# Altium schematic sheet Misc.SchDoc(Misc)
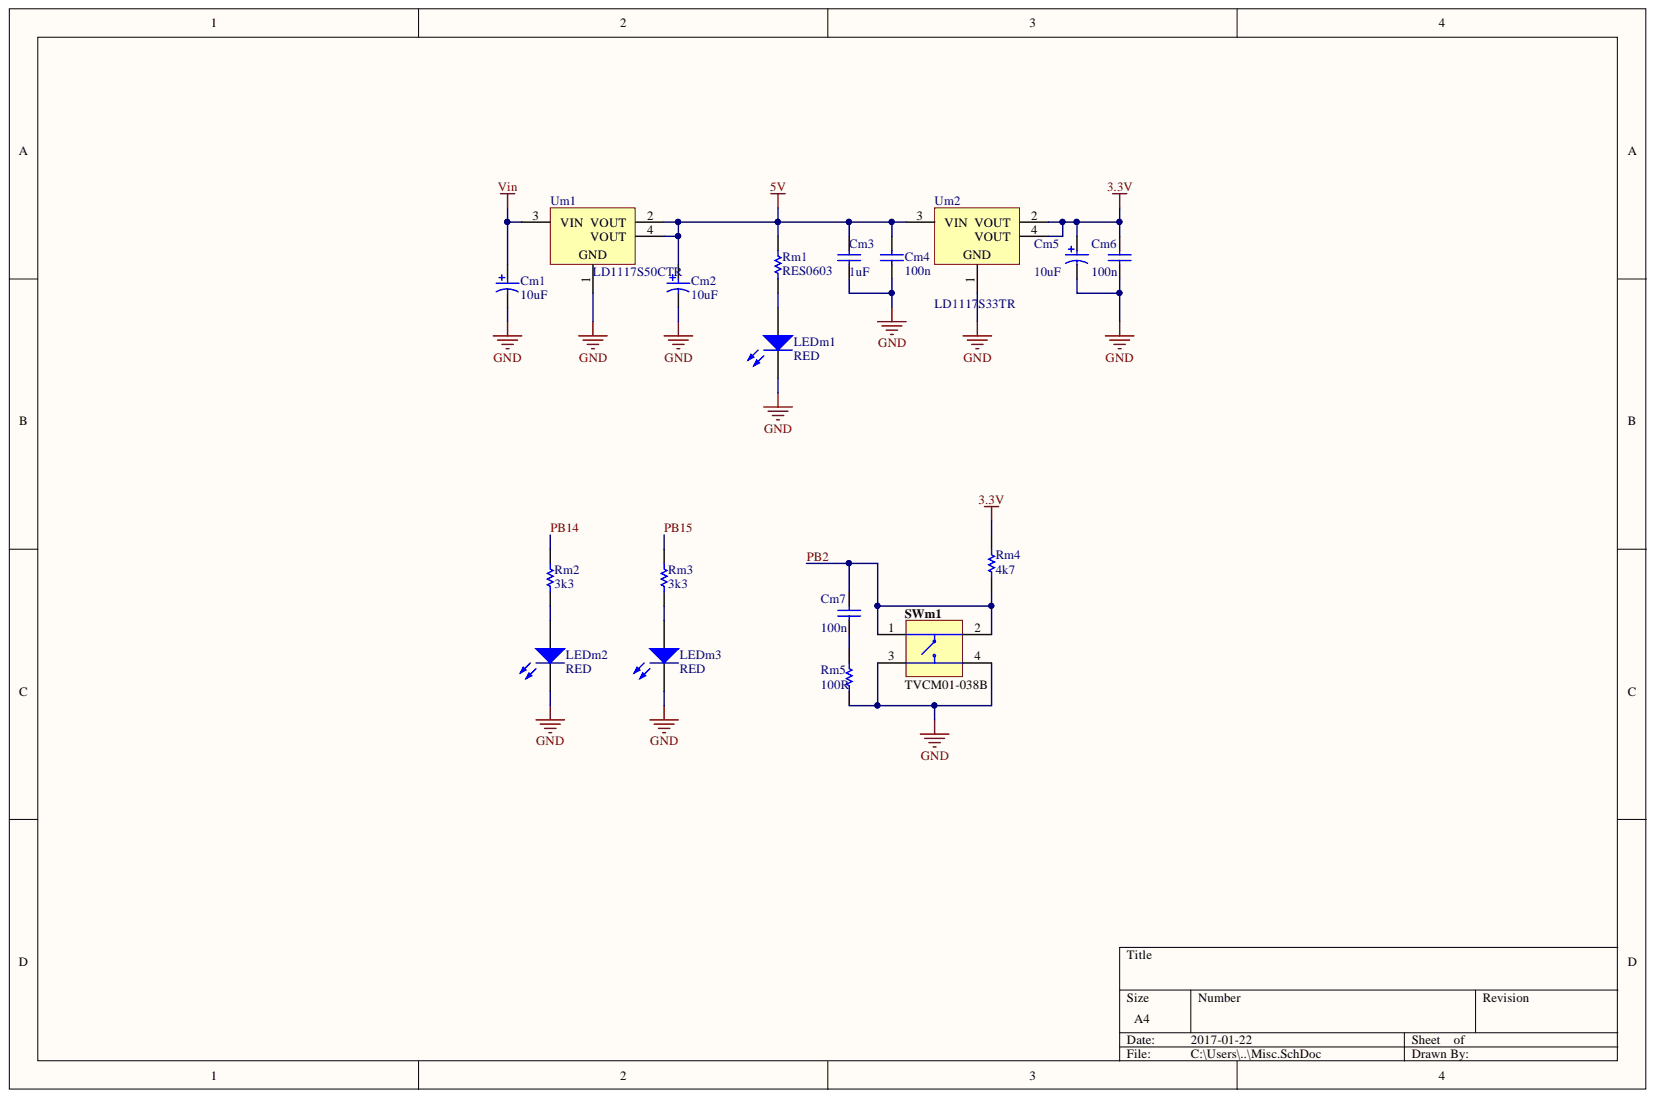
[diagram p0: Misc.SchDoc]

## Parts
Cm1  10uF  [CAPMP3217X18-T_V]
Cm2  10uF  [CAPMP3217X18-T_V]
Cm3  1uF  [CAPC0603(1608)100_L]
Cm4  100n  [CAPC0603(1608)100_L]
Cm5  10uF  [CAPMP3217X18-T_V]
Cm6  100n  [CAPC0603(1608)100_L]
Cm7  100n  [CAPC0603(1608)100_L]
LEDm1  RED  [0805_DIODE]
LEDm2  RED  [0805_DIODE]
LEDm3  RED  [0805_DIODE]
Rm1  RES0603  [RESC0603(1608)_L]
Rm2  3k3  [RESC0603(1608)_L]
Rm3  3k3  [RESC0603(1608)_L]
Rm4  4k7  [RESC0603(1608)_L]
Rm5  100R  [RESC0603(1608)_L]
SWm1  TVCM01-038B  [JNFU-TVCM01-038B_V]
Um1  LD1117S50CTR  [SOT-223_N]
Um2  LD1117S33TR  [SOT-223_L]

## Nets
3.3V: Cm5.1 Cm6.2 Rm4.2 Um2.2(VOUT) Um2.4(VOUT)
5V: Cm2.1 Cm3.2 Cm4.2 Rm1.2 Um1.2(VOUT) Um1.4(VOUT) Um2.3(VIN)
GND: Cm1.2 Cm2.2 Cm3.1 Cm4.1 Cm5.2 Cm6.1 LEDm1.2 LEDm2.2 LEDm3.2 Rm5.1 SWm1.3 SWm1.4 Um1.1 Um2.1
Vin: Cm1.1 Um1.3(VIN)
PB2: Cm7.2 Rm4.1 SWm1.1 SWm1.2
PB14: Rm2.2
PB15: Rm3.2
NetCm7_1: Cm7.1 Rm5.2
NetLEDm1_1: LEDm1.1 Rm1.1
NetLEDm2_1: LEDm2.1 Rm2.1
NetLEDm3_1: LEDm3.1 Rm3.1

## Library data of the parts
Cm1 Cm2 Cm5 = CMP-1661-00003-1: Standard Tantalum, 1 uF, +/- 10%, 35 V, -55 to 125 degC, 2-Pin SMD (1206), RoHS, Tape and Reel; Voltage Rating (DC): 30 V; Current Rating: 200 mA; Width: 4 mm; RoHSCompliant: true; REACH SVHC: No SVHC; Pins: 3; Packaging: Bulk; PackageReference: CAPMP3217X18-T; Package Quantity: 1000; PackageDescription: 2-Pin Surface Mount Device, Body 3.2 x 1.65 mm; Mount: Through Hole; Min Operating Temperature: -20 degC; Max Operating Temperature: 70 degC; Library Name: Altium Content Vault; Length: 11.6 mm; Height: 7.4 mm; DatasheetVersion: Feb-16; Contact Plating: Silver; Contact Material: Copper; Contact Finish: Silver; ComponentLink3URL: http://www.avx.com/download/software/literature/AVX-Footprint-Library.pdf; ComponentLink3Description: Package Specification; ComponentLink2URL: http://datasheets.avx.com/TAJ.pdf; ComponentLink2Description: Datasheet; ComponentLink1URL: http://www.avx.com/; ComponentLink1Description: Manufacturer URL; Component Kind: Standard
Cm3 Cm4 Cm6 Cm7 = CMP-1590-00005-1: 0603 (1608 Metric) Chip Capacitor; Case-Metric: 1608; Case-EIA: 0603; PackageReference: CAPC0603(1608)100; Library Name: Altium Content Vault; Component Kind: Standard
LEDm1-LEDm3 = LED: Light-emitting diode; Library Name: Library_Altium.IntLib; Component Kind: Standard; COLOR: RED
Rm1-Rm5 = CMP-1591-00001-1: 0603 (1608 Metric) Chip Resistor; Case-Metric: 1608; Case-EIA: 0603; PackageReference: RESC0603(1608); Library Name: Altium Content Vault; Component Kind: Standard
SWm1 = CMP-0224-00001-1: Switch, Push Button, 50 mA, 12V DC, SMD; Mechanical Life: 100,000 cycles; PartNumber: TVCM01-038B; PackageReference: TVCM01-038B; PackageDescription: 4-Pin Surface Mount Switch, 4.5 x 4.5 mm Body; Operating Temperature: -30 to 85 degC; Mounting Technology: Suface Mount; Library Name: Altium Content Vault; ComponentLink2URL: http://www.jian-fu.com/product-61.html; ComponentLink2Description: Datasheet; ComponentLink1URL: http://www.jian-fu.com/; ComponentLink1Description: Manufacturer URL; Component Kind: Standard; Case Size: 4.5 x 4.5
Um1 = CMP-0244-00311-1: Fixed Low Drop Positive Voltage Regulator, 5V, 4-Pin SOT-223, Tape and Reel; PackageVersion: 2010; PackageReference: SOT-223; PackageDescription: 4-Pin SOT-223 Package, 6.5 x 3.5 mm Body, 2.3 mm Pitch, 7 mm Lead Span; Library Name: Altium Content Vault; DatasheetVersion: 1/11/2011; ComponentLink2URL: http://www.st.com/internet/com/TECHNICAL_RESOURCES/TECHNICAL_LITERATURE/DATASHEET/CD00000544.pdf; ComponentLink2Description: Datasheet; ComponentLink1URL: http://www.st.com; ComponentLink1Description: Manufacturer URL; Component Kind: Standard
Um2 = CMP-0244-00310-1: Fixed Low Drop Positive Voltage Regulator, 3.3V, 4-Pin SOT-223, Tape and Reel; PackageVersion: 2010; PackageReference: SOT-223; PackageDescription: 4-Pin SOT-223 Package, 6.5 x 3.5 mm Body, 2.3 mm Pitch, 7 mm Lead Span; Library Name: Altium Content Vault; DatasheetVersion: 1/11/2011; ComponentLink2URL: http://www.st.com/internet/com/TECHNICAL_RESOURCES/TECHNICAL_LITERATURE/DATASHEET/CD00000544.pdf; ComponentLink2Description: Datasheet; ComponentLink1URL: http://www.st.com; ComponentLink1Description: Manufacturer URL; Component Kind: Standard
